# Altium schematic sheet 4G_EC20.SchDoc(4G_EC20)
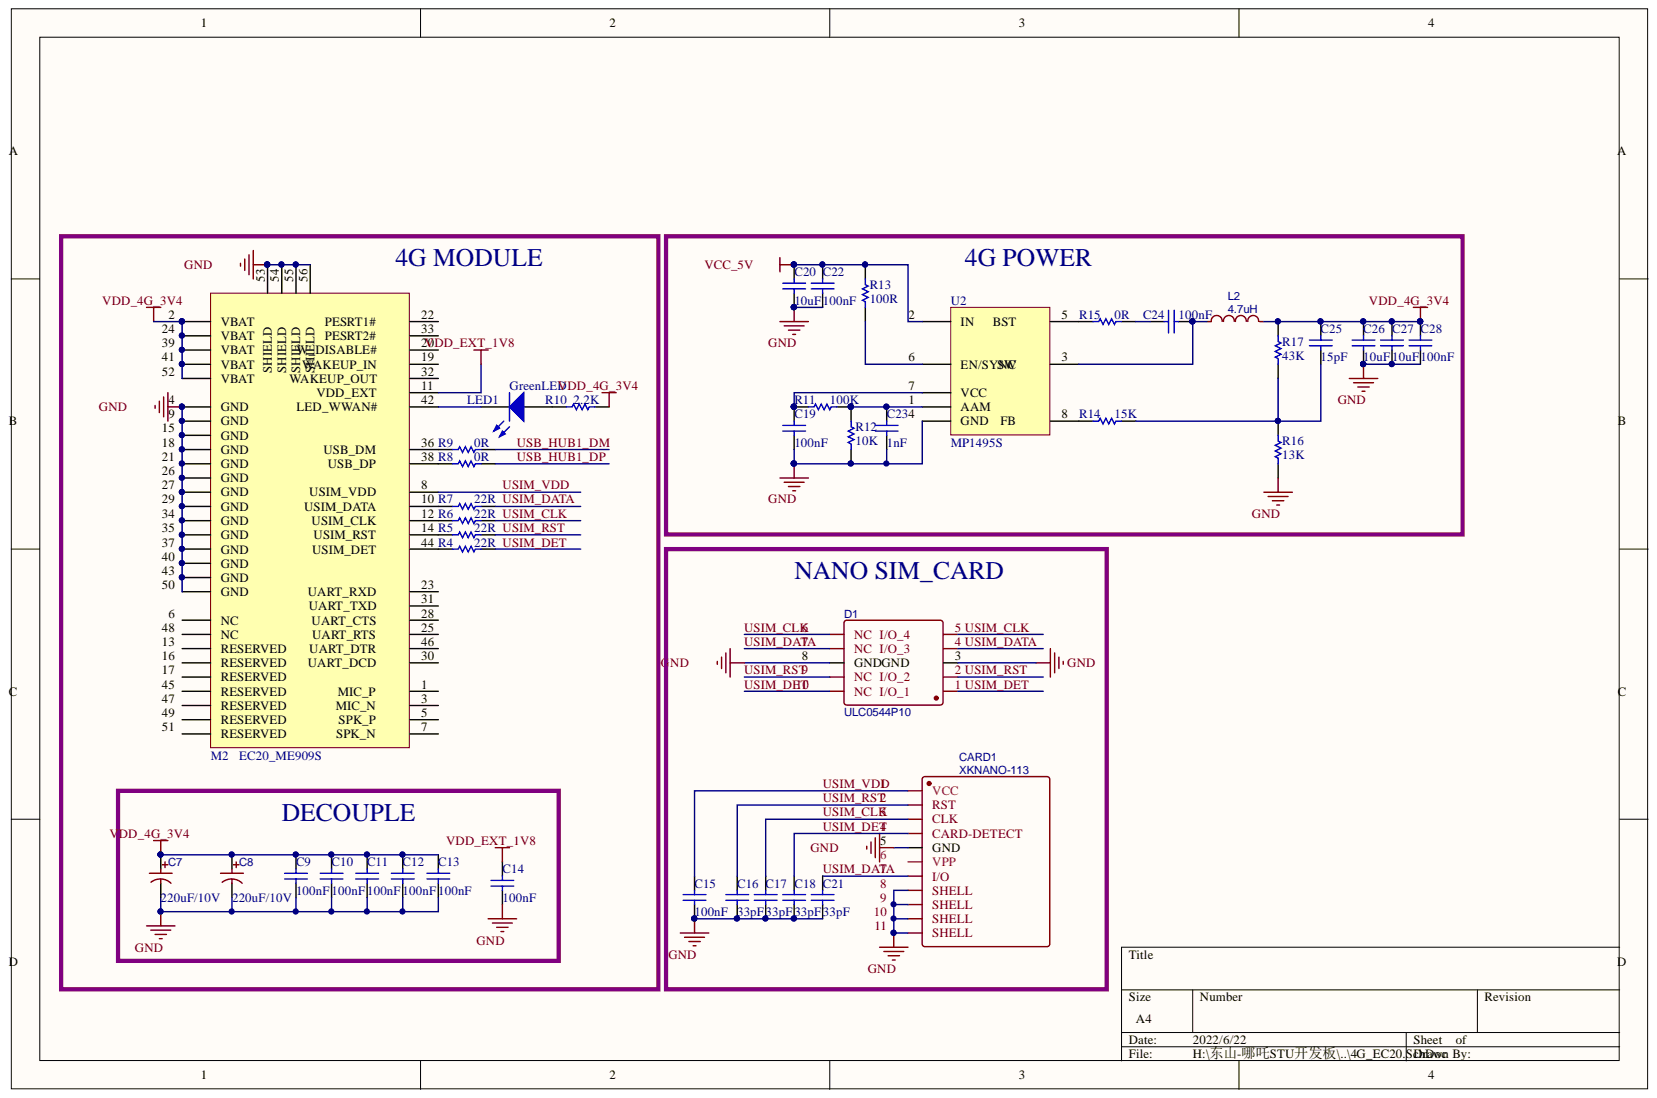
[diagram p0: 4G_EC20.SchDoc]

## Parts
C7  220uF/10V  [CAP-SMD_BD6.3-L6.6-W6.6-FD]
C8  220uF/10V  [CAP-SMD_BD6.3-L6.6-W6.6-FD]
C9  100nF  [0402]
C10  100nF  [0402]
C11  100nF  [0402]
C12  100nF  [0402]
C13  100nF  [0402]
C14  100nF  [0402]
C15  100nF  [0402]
C16  33pF  [0402]
C17  33pF  [0402]
C18  33pF  [0402]
C19  100nF  [0402]
C20  10uF  [0402]
C21  33pF  [0402]
C22  100nF  [0402]
C23  1nF  [0402]
C24  100nF  [0402]
C25  15pF  [0402]
C26  10uF  [0402]
C27  10uF  [0402]
C28  100nF  [0402]
CARD1  XKNANO-113  [SIM-SMD_XKNANO-113]
D1  ULC0544P10  [DFN2510-10_L2.5-W1.0-P0.50-BL]
L2  4.7uH  [IND-SMD_L6.0-W6.0]
LED1  GreenLED  [LED0402]
M2  EC20_ME909S  [EC200T_MINIPCI]
R4  22R  [0402]
R5  22R  [0402]
R6  22R  [0402]
R7  22R  [0402]
R8  0R  [0402]
R9  0R  [0402]
R10  2.2K  [0402]
R11  100K  [0402]
R12  10K  [0402]
R13  100R  [0402]
R14  15K  [0402]
R15  0R  [0402]
R16  13K  [0402]
R17  43K  [0402]
U2  MP1495S  [TSOT-23-8]

## Nets
GND: C7.2 C8.2 C9.2 C10.2 C11.2 C12.2 C13.2 C14.2 C15.1 C16.1 C17.1 C18.1 C19.2 C20.2 C21.1 C22.2 C23.2 C26.2 C27.2 C28.2 CARD1.5(GND) CARD1.8(SHELL) CARD1.9(SHELL) CARD1.10(SHELL) CARD1.11(SHELL) D1.3 D1.8 M2.4(GND) M2.9(GND) M2.15(GND) M2.18(GND) M2.21(GND) M2.26(GND) M2.27(GND) M2.29(GND) M2.34(GND) M2.35(GND) M2.37(GND) M2.40(GND) M2.43(GND) M2.50(GND) M2.53 M2.54 M2.55 M2.56 R12.2 R16.2 U2.4(GND)
VCC_5V: C20.1 C22.1 R13.1 U2.2(IN)
VDD_4G_3V4: C7.1 C8.1 C9.1 C10.1 C11.1 C12.1 C13.1 C25.1 C26.1 C27.1 C28.1 L2.2 M2.2(VBAT) M2.24(VBAT) M2.39(VBAT) M2.41(VBAT) M2.52(VBAT) R10.2 R17.1
VDD_EXT_1V8: C14.1 M2.11
USB_HUB1_DM: R9.1
USB_HUB1_DP: R8.1
USIM_CLK: C17.2 CARD1.3(CLK) D1.5 D1.6(NC) R6.1
USIM_DATA: C21.2 CARD1.7(I/O) D1.4 D1.7(NC) R7.1
USIM_DET: C18.2 CARD1.4(CARD-DETECT) D1.1 D1.10(NC) R4.1
USIM_RST: C16.2 CARD1.2(RST) D1.2 D1.9(NC) R5.1
USIM_VDD: C15.2 CARD1.1(VCC) M2.8
NetC19_1: C19.1 R11.2 U2.7(VCC)
NetC23_1: C23.1 R11.1 R12.1 U2.1(AAM)
NetC24_1: C24.1 R15.1
NetC24_2: C24.2 L2.1 U2.3
NetC25_2: C25.2 R14.1 R16.1 R17.2
NetLED1_1: LED1.1 R10.1
NetLED1_2: LED1.2 M2.42
NetM2_10: M2.10 R7.2
NetM2_12: M2.12 R6.2
NetM2_14: M2.14 R5.2
NetM2_36: M2.36 R9.2
NetM2_38: M2.38 R8.2
NetM2_44: M2.44 R4.2
NetR13_2: R13.2 U2.6(EN/SYNC)
NetR14_2: R14.2 U2.8
NetR15_2: R15.2 U2.5

Not connected: CARD1 6(VPP); M2 1 3 5 6(NC) 7 13(RESERVED) 16(RESERVED) 17(RESERVED) 19 20 22 23 25 28 30 31 32 33 45(RESERVED) 46 47(RESERVED) 48(NC) 49(RESERVED) 51(RESERVED)

## Library data of the parts
C7 C8 = 100uF: BOM_Manufacturer: ReliaPro; BOM_Manufacturer Part: 100uF 25V; nameAlias: Capacitance; BOM_Supplier: LCSC; BOM_Supplier Part: C13376; Component Kind: Standard; spicePre: C; spiceSymbolName: 100uF 25V; Value: 220uF/10V
C9-C28 = Cap: Capacitor; PartNumber: CAP100NF; Component Kind: Standard; LatestRevisionDate: 17-Jul-2002; LatestRevisionNote: Re-released for DXP Platform.; Library Name: Miscellaneous Devices.IntLib; PackageReference: 0402; Published: 8-Jun-2000; Signal Integrity: Cap; Simulation: CAP; Publisher: Altium Limited
  C9-C15 C19 C22 C24 C28: Value: 100nF
  C16-C18 C21: Value: 33pF
  C20 C26 C27: Value: 10uF
  C23: Value: 1nF
  C25: Value: 15pF
CARD1 = XKNANO-113: BOM_Manufacturer: XKB Enterprise; Contributor: LCSC; BOM_JLCPCB Part Class: Extended Part; BOM_Manufacturer Part: XKNANO-113; BOM_Supplier: LCSC; BOM_Supplier Part: C381071; Component Kind: Standard; spicePre: C; spiceSymbolName: XKNANO-113
D1 = ULC0544P10: BOM_Manufacturer: Leiditech; Contributor: LCSC; BOM_JLCPCB Part Class: Extended Part; BOM_Manufacturer Part: ULC0544P10; BOM_Supplier: LCSC; BOM_Supplier Part: C384893; Component Kind: Standard; Library Name: HM2.SchLib; spicePre: D; spiceSymbolName: ULC0544P10
L2 = 4.7uH: BOM_Manufacturer: Sunltech Tech; BOM_Manufacturer Part: SLW6028S4R7MST; Contributor: LCSC; nameAlias: Inductance; BOM_JLCPCB Part Class: Extended Part; BOM_Supplier: LCSC; BOM_Supplier Part: C206281; Component Kind: Standard; spicePre: L; spiceSymbolName: SLW6028S4R7MST
LED1 = LED2: Typical RED, GREEN, YELLOW, AMBER GaAs LED; Component Kind: Standard; LatestRevisionDate: 17-Jul-2002; LatestRevisionNote: Re-released for DXP Platform.; Library Name: Miscellaneous Devices.IntLib; PackageDescription: SM LED; 2 Flat Leads; PackageReference: 3.2X1.6X1.1; PackageVersion: Feb-2002; Published: 8-Jun-2000; Simulation: LED2; Value: GreenLED; Publisher: Altium Limited
R4-R9 R11-R17 = Res1: Resistor; PartNumber: RES22R; Component Kind: Standard; LatestRevisionDate: 17-Jul-2002; LatestRevisionNote: Re-released for DXP Platform.; Library Name: Miscellaneous Devices.IntLib; PackageReference: 0402; Published: 8-Jun-2000; Signal Integrity: Res; Simulation: RESISTOR; Publisher: Altium Limited
  R4-R7: Value: 22R
  R8 R9 R15: Value: 0R
  R11: Value: 100K
  R12: Value: 10K
  R13: Value: 100R
  R14: Value: 15K
  R16: Value: 13K
  R17: Value: 43K
R10 = Res1: Resistor; PartNumber: RES2.2K; Component Kind: Standard; LatestRevisionDate: 17-Jul-2002; LatestRevisionNote: Re-released for DXP Platform.; Library Name: Miscellaneous Devices.IntLib; PackageReference: 0402; Published: 8-Jun-2000; Signal Integrity: Res; Simulation: RESISTOR; Value: 2.2K; Publisher: Altium Limited
M2 = EC20_ME909S: Component Kind: Standard; Library Name: HM2.SchLib
U2 = MP1495S: Component Kind: Standard; Library Name: HM2.SchLib; Value: MP1495S
